# Altium schematic sheet SENSOR.SchDoc
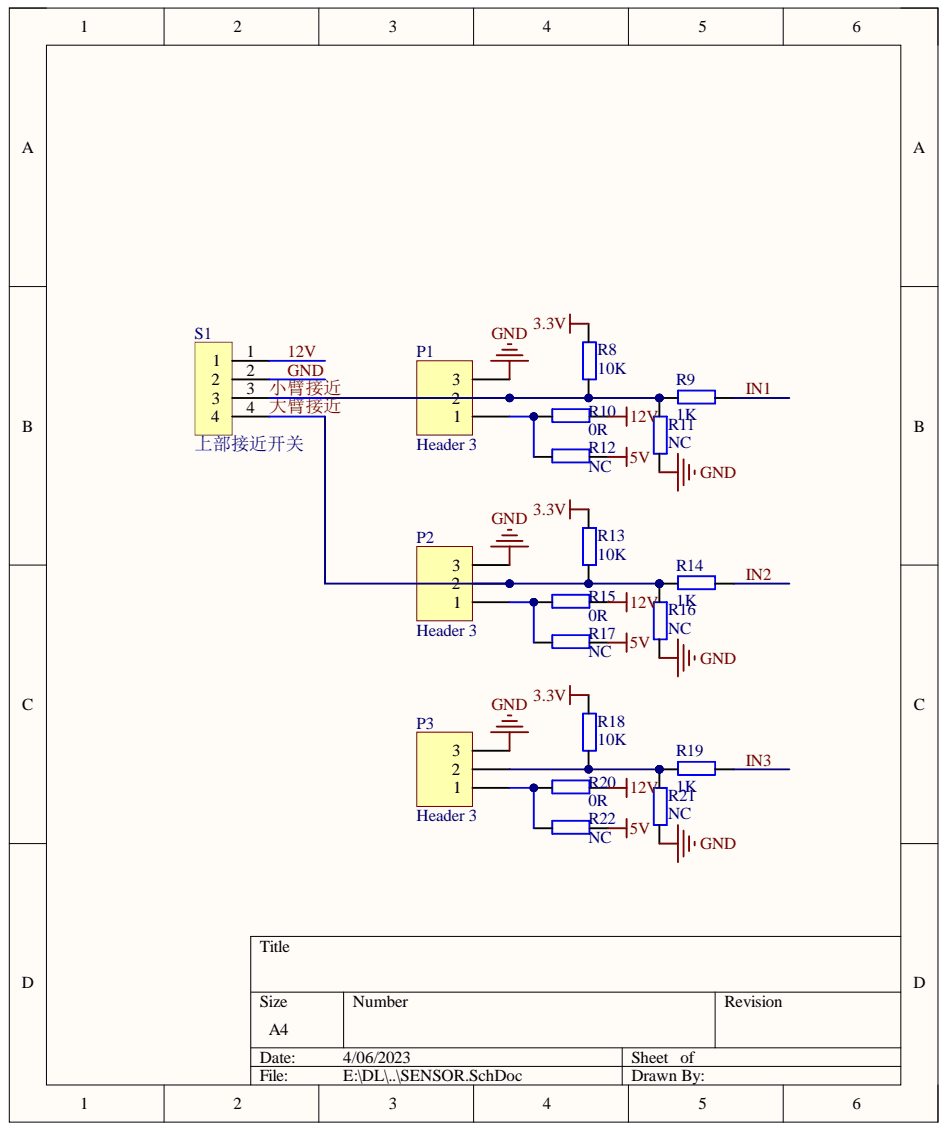
[diagram p0: SENSOR.SchDoc]

## Parts
P1  Header 3  [卧式PH2.0mm-3P]
P2  Header 3  [卧式PH2.0mm-3P]
P3  Header 3  [卧式PH2.0mm-3P]
R8  10K  [0402_R]
R9  1K  [0402_R]
R10  0R  [0402_R]
R11  NC  [0402_R]
R12  NC  [0402_R]
R13  10K  [0402_R]
R14  1K  [0402_R]
R15  0R  [0402_R]
R16  NC  [0402_R]
R17  NC  [0402_R]
R18  10K  [0402_R]
R19  1K  [0402_R]
R20  0R  [0402_R]
R21  NC  [0402_R]
R22  NC  [0402_R]
S1  上部接近开关  [卧式PH2.0mm-4P]

## Nets
3.3V: R8.2 R13.2 R18.2
5V: R12.1 R17.1 R22.1
12V: R10.1 R15.1 R20.1 S1.1
GND: P1.3 P2.3 P3.3 R11.1 R16.1 R21.1 S1.2
IN1: R9.1
IN2: R14.1
IN3: R19.1
大臂接近: P2.2 R13.1 R14.2 R16.2 S1.4
小臂接近: P1.2 R8.1 R9.2 R11.2 S1.3
NetP1_1: P1.1 R10.2 R12.2
NetP2_1: P2.1 R15.2 R17.2
NetP3_1: P3.1 R20.2 R22.2
NetP3_2: P3.2 R18.1 R19.2 R21.2

## Library data of the parts
P1-P3 = Header 3: Header, 3-Pin; Component Kind: Standard; LatestRevisionDate: 17-Jul-2002; LatestRevisionNote: Re-released for DXP Platform.; Library Name: Miscellaneous Connectors.IntLib; Signal Integrity: Connector; Publisher: Altium Limited
R8-R22 = R: Component Kind: Standard; Library Name: WARSHIP.SCHLIB
S1 = S-4: Component Kind: Standard; Library Name: ZBC123Schlib1.SchLib
